# Altium schematic sheet Battery_Operated Node.SchDoc
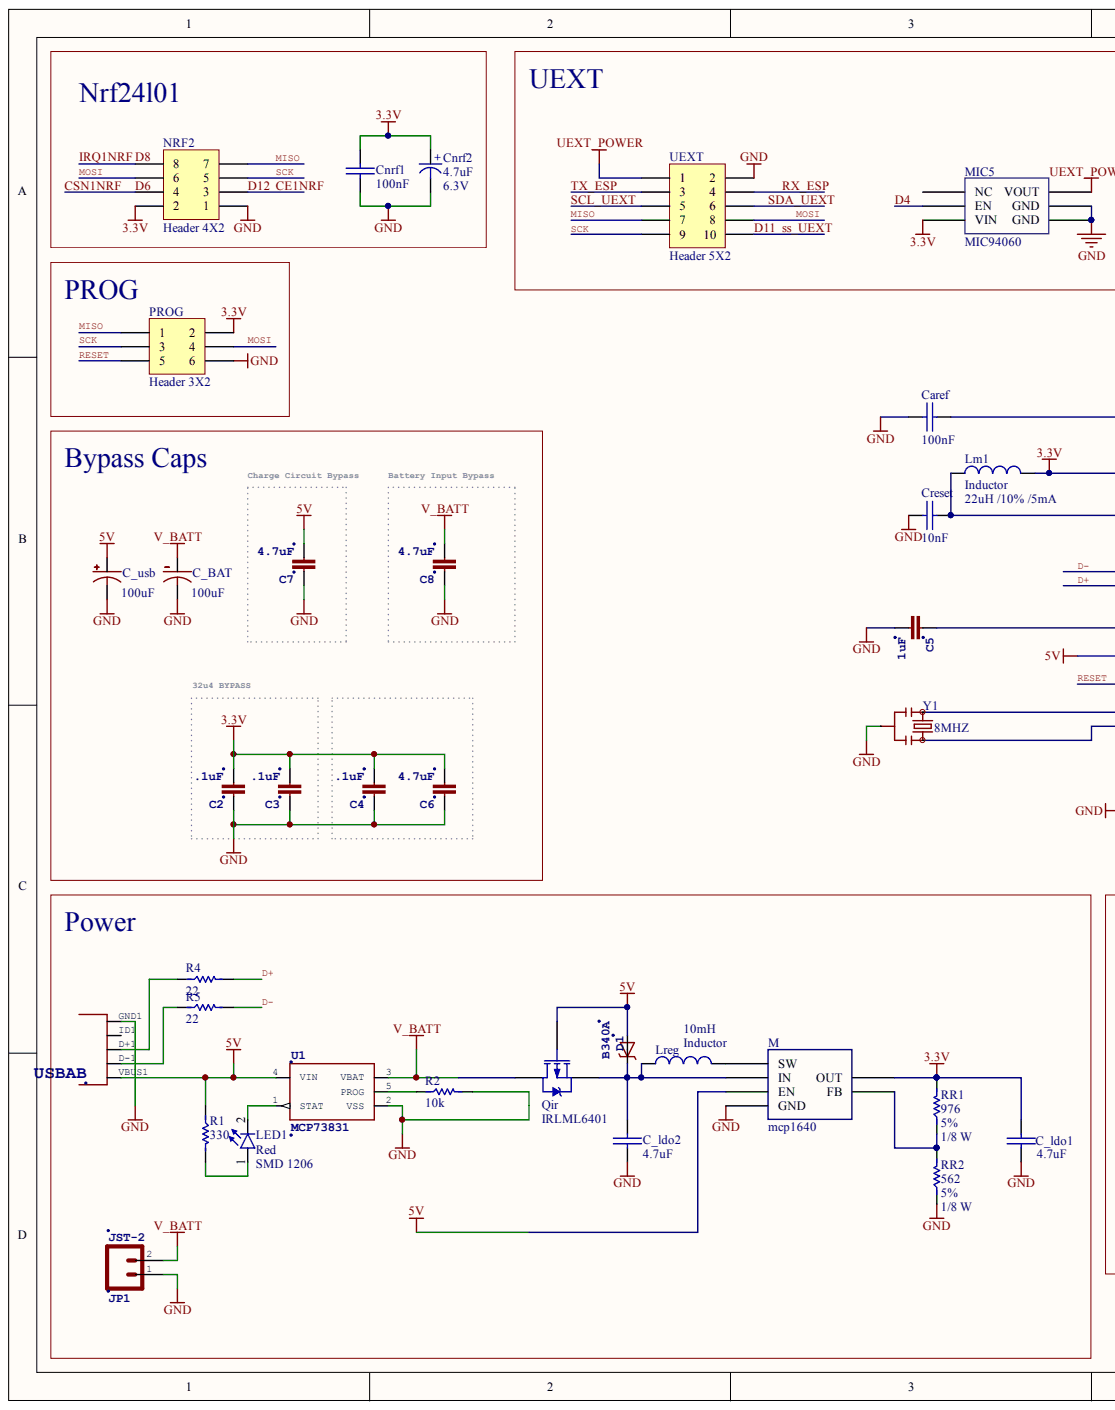
[diagram p0: Battery_Operated Node.SchDoc, left]
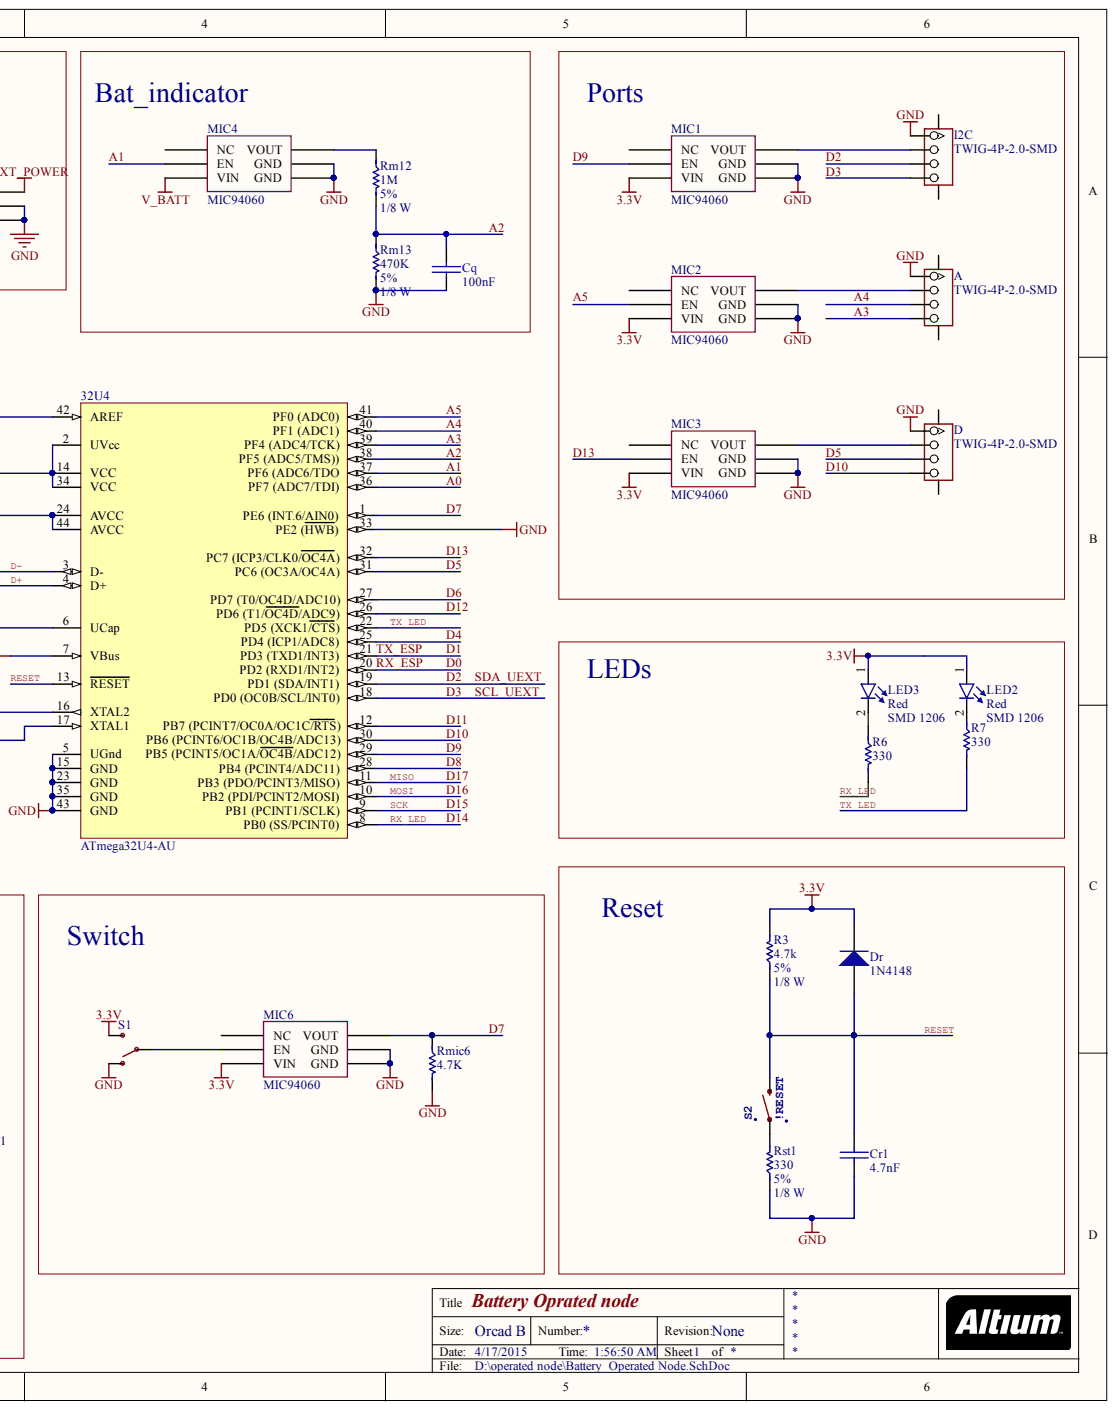
[diagram p1: Battery_Operated Node.SchDoc, right]

## Parts
32U4  ATmega32U4-AU  [44ML_N]
A  TWIG-4P-2.0-SMD  [4P-SMD-2.0]
C2  .1uF  [0603-CAP]
C3  .1uF  [0603-CAP]
C4  .1uF  [0603-CAP]
C5  1uF  [0603-CAP]
C6  4.7uF  [0603-CAP]
C7  4.7uF  [1206]
C8  4.7uF  [1206]
C_BAT  100uF  [PANASONIC_D]
C_ldo1  4.7uF  [SMD_CAP_1206]
C_ldo2  4.7uF  [SMD_CAP_1206]
C_usb  100uF  [PANASONIC_D]
Caref  100nF  [SMD_CAP_0805]
Cnrf1  100nF  [SMD_CAP_0805]
Cnrf2  4.7uF  Voltage: 6.3V  [CAPP 1206 (3216X18L) Size A]
Cq  100nF  [SMD_CAP_0805]
Cr1  4.7nF  [SMD_CAP_0805]
Creset  10nF  [SMD_CAP_0805]
D  TWIG-4P-2.0-SMD  [4P-SMD-2.0]
D1  B340A  [SMA-DIODE]
Dr  1N4148  [LL4148]
I2C  TWIG-4P-2.0-SMD  [4P-SMD-2.0]
JP1  JST-2  [JST-2-SMD]
LED1  Red  Size: SMD 1206  [LED SMD 1206]
LED2  Red  Size: SMD 1206  [LED SMD 1206]
LED3  Red  Size: SMD 1206  [LED SMD 1206]
Lm1  Inductor  value 22uH /10% /5mA  [INDC3216]
Lreg  Inductor  value 10mH  [INDC4532]
M  mcp1640  [MCP1640]
MIC1  MIC94060  [mic94060]
MIC2  MIC94060  [mic94060]
MIC3  MIC94060  [mic94060]
MIC4  MIC94060  [mic94060]
MIC5  MIC94060  [mic94060]
MIC6  MIC94060  [mic94060]
NRF2  Header 4X2  [HDR2X4]
PROG  Header 3X2  [HDR2X3]
Qir  IRLML6401  [Micro3_N]
R1  330  [RES 0805 (2012X06L)]
R2  10k  [RES 0805 (2012X06L)]
R3  4.7k  Power: 1/8 W  Tolerance: 5%  [RES 0805 (2012X06L)]
R4  22  [RES 0805 (2012X06L)]
R5  22  [RES 0805 (2012X06L)]
R6  330  [RES 0805 (2012X06L)]
R7  330  [RES 0805 (2012X06L)]
Rm12  1M  Power: 1/8 W  Tolerance: 5%  [RES 0805 (2012X06L)]
Rm13  470K  Power: 1/8 W  Tolerance: 5%  [RES 0805 (2012X06L)]
Rmic6  4.7K  [RES 0805 (2012X06L)]
RR1  976  Power: 1/8 W  Tolerance: 5%  [RES 0805 (2012X06L)]
RR2  562  Power: 1/8 W  Tolerance: 5%  [RES 0805 (2012X06L)]
Rst1  330  Power: 1/8 W  Tolerance: 5%  [RES 0805 (2012X06L)]
S1  (no value)  [SWITCH-SPDT-SMD]
S2  !RESET  [TACTILE_SWITCH_SMD]
U1  MCP73831  [SOT23-5]
U5  USB-ABCONN-11794  [USB-AB-MICRO-SMD_V03]  (value not drawn)
UEXT  Header 5X2  [HDR2X5]
Y1  8MHZ  [RESONATOR-SMD]

## Nets
3.3V: 32U4.2(UVcc) 32U4.14(VCC) 32U4.34(VCC) C2.2 C3.2 C4.2 C6.2 C_ldo1.1 Cnrf1.1 Cnrf2.1 Dr.2 LED2.1 LED3.1 Lm1.2 M.5(OUT) MIC1.4(VIN) MIC2.4(VIN) MIC3.4(VIN) MIC5.4(VIN) MIC6.4(VIN) NRF2.2 PROG.2 R3.2 RR1.2 S1.3
5V: 32U4.7(VBus) C7.2 C_usb.+ D1.A M.3(EN) Qir.1 R1.1 U1.4(VIN) U5.VBUS1
GND: 32U4.5(UGnd) 32U4.15(GND) 32U4.23(GND) 32U4.33(PE2_(HWB)) 32U4.35(GND) 32U4.43(GND) A.1 C2.1 C3.1 C4.1 C5.2 C6.1 C7.1 C8.1 C_BAT.- C_ldo1.2 C_ldo2.2 C_usb.- Caref.1 Cnrf1.2 Cnrf2.2 Cq.2 Cr1.2 Creset.1 D.1 I2C.1 JP1.1 M.2(GND) MIC1.2(GND) MIC1.5(GND) MIC2.2(GND) MIC2.5(GND) MIC3.2(GND) MIC3.5(GND) MIC4.2(GND) MIC4.5(GND) MIC5.2(GND) MIC5.5(GND) MIC6.2(GND) MIC6.5(GND) NRF2.1 PROG.6 R2.2 Rm13.2 Rmic6.2 RR2.1 Rst1.1 S1.1 U1.2(VSS) U5.GND1 UEXT.2 Y1.2
UEXT_POWER: MIC5.1(VOUT) UEXT.1
V_BATT: C8.2 C_BAT.+ JP1.2 MIC4.4(VIN) Qir.3 U1.3(VBAT)
A0: 32U4.36(PF7_(ADC7/TDI))
A1: 32U4.37(PF6_(ADC6/TDO) MIC4.3(EN)
A2: 32U4.38(PF5_(ADC5/TMS))) Cq.1 Rm12.2 Rm13.1
A3: 32U4.39(PF4_(ADC4/TCK)) A.4
A4: 32U4.40(PF1_(ADC1)) A.3
A5: 32U4.41(PF0_(ADC0)) MIC2.3(EN)
CE1NRF: 32U4.26(PD6_(T1/OC4D/ADC9)) NRF2.3
CSN1NRF: 32U4.27(PD7_(T0/OC4D/ADC10)) NRF2.4
D0: 32U4.20(PD2_(RXD1/INT2)) UEXT.4
D1: 32U4.21(PD3_(TXD1/INT3)) UEXT.3
D2: 32U4.19(PD1_(SDA/INT1)) I2C.3 UEXT.6
D3: 32U4.18(PD0_(OC0B/SCL/INT0)) I2C.4 UEXT.5
D4: 32U4.25(PD4_(ICP1/ADC8)) MIC5.3(EN)
D5: 32U4.31(PC6_(OC3A/OC4A)) D.3
D7: 32U4.1(PE6_(INT.6/AIN0)) MIC6.1(VOUT) Rmic6.1
D8: 32U4.28(PB4_(PCINT4/ADC11)) NRF2.8
D9: 32U4.29 MIC1.3(EN)
D10: 32U4.30(PB6_(PCINT6/OC1B/OC4B/ADC13)) D.4
D11: 32U4.12 UEXT.10
D13: 32U4.32(PC7_(ICP3/CLK0/OC4A)) MIC3.3(EN)
D14: 32U4.8(PB0_(SS/PCINT0)) R6.2
D15: 32U4.9(PB1_(PCINT1/SCLK)) NRF2.5 PROG.3 UEXT.9
D16: 32U4.10 NRF2.6 PROG.4 UEXT.8
D17: 32U4.11 NRF2.7 PROG.1 UEXT.7
D+: 32U4.4(D+) R4.2
D-: 32U4.3(D-) R5.2
RESET: 32U4.13(RESET) Cr1.1 Dr.1 PROG.5 R3.1 S2.3
TX_LED: 32U4.22(PD5_(XCK1/CTS)) R7.2
Net32U4_6: 32U4.6(UCap) C5.1
Net32U4_16: 32U4.16(XTAL2) Y1.1
Net32U4_17: 32U4.17(XTAL1) Y1.3
Net32U4_24: 32U4.24(AVCC) 32U4.44(AVCC) Creset.2 Lm1.1
Net32U4_42: 32U4.42(AREF) Caref.2
NetA_2: A.2 MIC2.1(VOUT)
NetC_ldo2_1: C_ldo2.1 D1.C Lreg.1 M.6(IN) Qir.2
NetD_2: D.2 MIC3.1(VOUT)
NetI2C_2: I2C.2 MIC1.1(VOUT)
NetLED1_1: LED1.1 R1.2
NetLED1_2: LED1.2 U1.1(STAT)
NetLED2_2: LED2.2 R7.1
NetLED3_2: LED3.2 R6.1
NetLreg_2: Lreg.2 M.1(SW)
NetM_4: M.4(FB) RR1.1 RR2.2
NetMIC4_1: MIC4.1(VOUT) Rm12.1
NetMIC6_3: MIC6.3(EN) S1.2
NetR2_1: R2.1 U1.5(PROG)
NetR4_1: R4.1 U5.D+1
NetR5_1: R5.1 U5.D-1
NetRst1_2: Rst1.2 S2.1

Not connected: A SS1 SS2; D SS1 SS2; I2C SS1 SS2; MIC1 6(NC); MIC2 6(NC); MIC3 6(NC); MIC4 6(NC); MIC5 6(NC); MIC6 6(NC); U5 ID1

## Library data of the parts
32U4 = ATmega32U4-AU: 8-bit AVR Microcontroller, 2.7-5.5V, 32KB Flash, 2.5KB EEPROM, 1KB SRAM, USB Controller, 44-pin TQFP, Industrial Grade (-40°C to 85°C); Component Kind: Standard; ComponentLink1Description: Manufacturer Link; ComponentLink1URL: http://www.atmel.com/products/avr/default.asp?; ComponentLink2Description: ATmega16U4/32U4 Datasheet; ComponentLink2URL: http://www.atmel.com/dyn/resources/prod_documents/doc7766.pdf; ComponentLink3Description: ATmega16U4 ATmega32U4 Summary; ComponentLink3URL: http://www.atmel.com/dyn/resources/prod_documents/7766S.pdf; ComponentLink4Description: Atmel.com Home; ComponentLink4URL: http://www.atmel.com; DatasheetVersion: revD, Jan-2009; Library Name: Atmel Microcontroller 8-Bit megaAVR.IntLib; PackageDescription: 44-Pin Plastic Thin Quad Flat Pack, 10 x 10 x 1.0 mm Body, 0.8 mm Pitch (TQFP); PackageReference: 44ML; PackageVersion: rev.G, Jul-2007; Published: 1-Apr-2010; Supplier 1: Digi-Key; Supplier 2: Farnell; Supplier 3: Mouser; Supplier Part Number 1: ATMEGA32U4-AU-ND; Supplier Part Number 2: 1748525; Supplier Part Number 3: 556-ATMEGA32U4-AU; Publisher: Altium Limited
A D I2C = TWIG-4P-2.0-SMD-FEMALE-REINFORCE'DD': Component Kind: Standard; Library Name: Connector.IntLib
C2-C4 = CAP0603-CAP: ceramic capacitor; PROD_ID: CAP-00810; Component Kind: Standard; Library Name: SparkFun-Passives.IntLib
C5 = CAP0603-CAP: ceramic capacitor; PROD_ID: CAP-00868; Component Kind: Standard; Library Name: SparkFun-Passives.IntLib
C6 = CAP0603-CAP: ceramic capacitor; PROD_ID: CAP-08280; Component Kind: Standard; Library Name: SparkFun-Passives.IntLib
C7 C8 = CAP1206: ceramic capacitor; PROD_ID: CAP-10300; Component Kind: Standard; Library Name: SparkFun-Passives.IntLib
C_BAT C_usb = CAP_POLD: Polar Capacitor 6.3V; Component Kind: Standard; Library Name: SparkFun-Capacitors.IntLib; VALUE: 100uF
C_ldo1 C_ldo2 Caref Cnrf1 Cq Cr1 Creset = Cap-Bipolar: ceramic capacitor; Designer: Saeid Jorablo; Organazation: ControTec; Voltage: 100V; Adding Date: 1387/12/20; Component Kind: Standard; Library Name: My Library.IntLib
  C_ldo1 C_ldo2: Value: 4.7uF
  Caref Cnrf1 Cq: Value: 100nF
  Cr1: Value: 4.7nF
  Creset: Value: 10nF
Cnrf2 = Cap-Polar: Polar Capacitor; Designer: Saeid Jorablo; Organazation: ControTec; Adding Date: 1387/12/20; Component Kind: Standard; Library Name: My Library.IntLib; Value: 4.7uF
D1 = DIODE-SCHOTTKY-B340A: Schottky diodes in SFE's production catalog<p> / BAT20J 1A 23V 0.62Vf<br> / RB751 120mA 40V 0.37Vf<br> / PMEG4005EJ 0.5A 40V 0.42Vf<br> / MBRA140 1A 40V 0.5Vf<br> / B340A  3A 40V SMA <br>; Component Kind: Standard; Library Name: SparkFun-DiscreteSemi.IntLib
Dr = Diode 1N4148: High Conductance Fast Diode; Code_JEDEC: DO-204-AH; Component Kind: Standard; ComponentLink1Description: Manufacturer Link; ComponentLink1URL: http://www.fairchildsemi.com/; ComponentLink2Description: Datasheet; ComponentLink2URL: http://www.fairchildsemi.com/ds/1N%2F1N4148.pdf; DatasheetVersion: 1997; LatestRevisionDate: 17-Jul-2002; LatestRevisionNote: Re-released for DXP Platform.; Library Name: My Library.IntLib; PackageDescription: Diode, Axial; Body 3.8 x 1.9 mm (LxDia. max), Lead Dia. 0.53 mm (max); PackageReference: DO-35; Published: 8-Jun-2000; Signal Integrity: 1N4148; Publisher: Altium Limited
JP1 = M02-JST-2MM-SMT: Standard 2-pin 0.1" header. Use with <br> / - straight break away headers ( PRT-00116)<br> / - right angle break away headers (PRT-00553)<br> / - swiss pins (PRT-00743)<br> / - machine pins (PRT-00117)<br> / - female headers (PRT-00115)<br><br> / Molex polarized connector foot print use with: PRT-08233 with associated crimp pins and housings.<br><br> / 2.54_SCREWTERM for use with  PRT-10571.<br><br> / 3.5mm Screw Terminal footprints for  PRT-08084<br><br> / 5mm Screw Terminal footprints for use with PRT-08433; PROD_ID: CONN-08352; Component Kind: Standard; Library Name: SparkFun-Connectors.IntLib
LED1-LED3 = LED: Designer: Saeid Jorablo; Organazation: ControTec; Adding Date: 1387/12/22; Color: Red; Component Kind: Standard; Description: LED; Library Name: My Library.IntLib
Lm1 Lreg = Inductor: Code_IEC: 1005; Code_JEITA: 0402; Component Kind: Standard; Description: Inductor; LatestRevisionDate: 29-May-2009; LatestRevisionNote: IPC-7351 Footprint Added.; Library Name: My Library.IntLib; PackageDescription: Chip Inductor; PackageReference: 0402-A; Published: 8-Jun-2000; Signal Integrity: Inductor; Publisher: Altium Limited
M = mcp1640: MCP1640DT-I/CHY , 0.65V, Sync Reg., 6LD SOT-23 pkg; Component Kind: Standard; Library Name: mcp1640.IntLib
MIC1-MIC6 = MIC94060: MIC94060YC6, SC-70-6; Component Kind: Standard; Library Name: mic94060.IntLib
NRF2 = Header 4X2: Header, 4-Pin, Dual row; Component Kind: Standard; Library Name: Miscellaneous Connectors.IntLib; Revision: 17-Jul-2002: Re-released for DXP Platform.; Signal Integrity: Connector; Publisher: Altium Limited
PROG = Header 3X2: Header, 3-Pin, Dual row; Component Kind: Standard; Library Name: Miscellaneous Connectors.IntLib; Revision: 17-Jul-2002: Re-released for DXP Platform.; Signal Integrity: Connector; Publisher: Altium Limited
Qir = IRLML6401: HEXFET Power MOSFET; Component Kind: Standard; ComponentLink1Description: Manufacturer Link; ComponentLink1URL: http://www.irf.com/; ComponentLink2Description: Datasheet; ComponentLink2URL: http://www.irf.com/product-info/datasheets/data/IRLML6401.pdf; DatasheetVersion: 2-Jul-2001; Library Name: IR Discrete MOSFET - Power.IntLib; PackageDescription: 3-Pin SOT-23 Package 0.95 mm Pitch, 2.3 mm Lead Span; PackageReference: Micro3; PackageVersion: Jul-2001; Published: 17-Sep-2003; Simulation: IRLML6401; Publisher: Altium Limited
R1 R2 R4-R7 Rmic6 = Resistor: Power: 1/8 W; Tolerance: 5%; Component Kind: Standard; Description: Resistor; Library Name: My Library.IntLib; Simulation: Model Name
  R1 R6 R7: Value: 330
  R2: Value: 10k
  R4 R5: Value: 22
  Rmic6: Value: 4.7K
R3 Rm12 Rm13 RR1 RR2 Rst1 = Resistor: Component Kind: Standard; Description: Resistor; Library Name: My Library.IntLib; Simulation: Model Name
  R3: Value: 4.7k
  Rm12: Value: 1M
  Rm13: Value: 470K
  RR1: Value: 976
  RR2: Value: 562
  Rst1: Value: 330
S1 = SWITCH-SPDTSMD2: Component Kind: Standard; Library Name: SparkFun-Electromechanical.IntLib
S2 = SWITCH-MOMENTARY-2SMD: Various NO switches- pushbuttons, reed, etc; Component Kind: Standard; Library Name: SparkFun-Electromechanical.IntLib
U1 = MCP73831: Miniature single cell, fully integrated Li-Ion, Li-polymer charge management controller<br> / http://ww1.microchip.com/downloads/en/DeviceDoc/21984a.pdf<br> / IC-09995; Component Kind: Standard; Library Name: SparkFun-PowerIC.IntLib; PROD_ID: IC-09995
U5 = USB-ABCONN-11794: This is a USB AB connector that is compatible with USB OTG. i.e. this connector can serve as a host or device; Component Kind: Standard; Library Name: SparkFun-Connectors.IntLib; PROD_ID: CONN-11794; USBAB: USBAB
UEXT = Header 5X2: Header, 5-Pin, Dual row; Component Kind: Standard; Library Name: Miscellaneous Connectors.IntLib; Revision: 17-Jul-2002: Re-released for DXP Platform.; Signal Integrity: Connector; Publisher: Altium Limited
Y1 = RESONATOR8MHZ: Resonator ,Small SMD resonator,Used, eg, on the Arduino Pro/ Pro Mini boards.  8MHz- XTAL-08895; Component Kind: Standard; PROD_ID: XTAL-08895; Library Name: SparkFun-FreqCtrl.SCHLIB
